# Altium schematic sheet Power.SchDoc
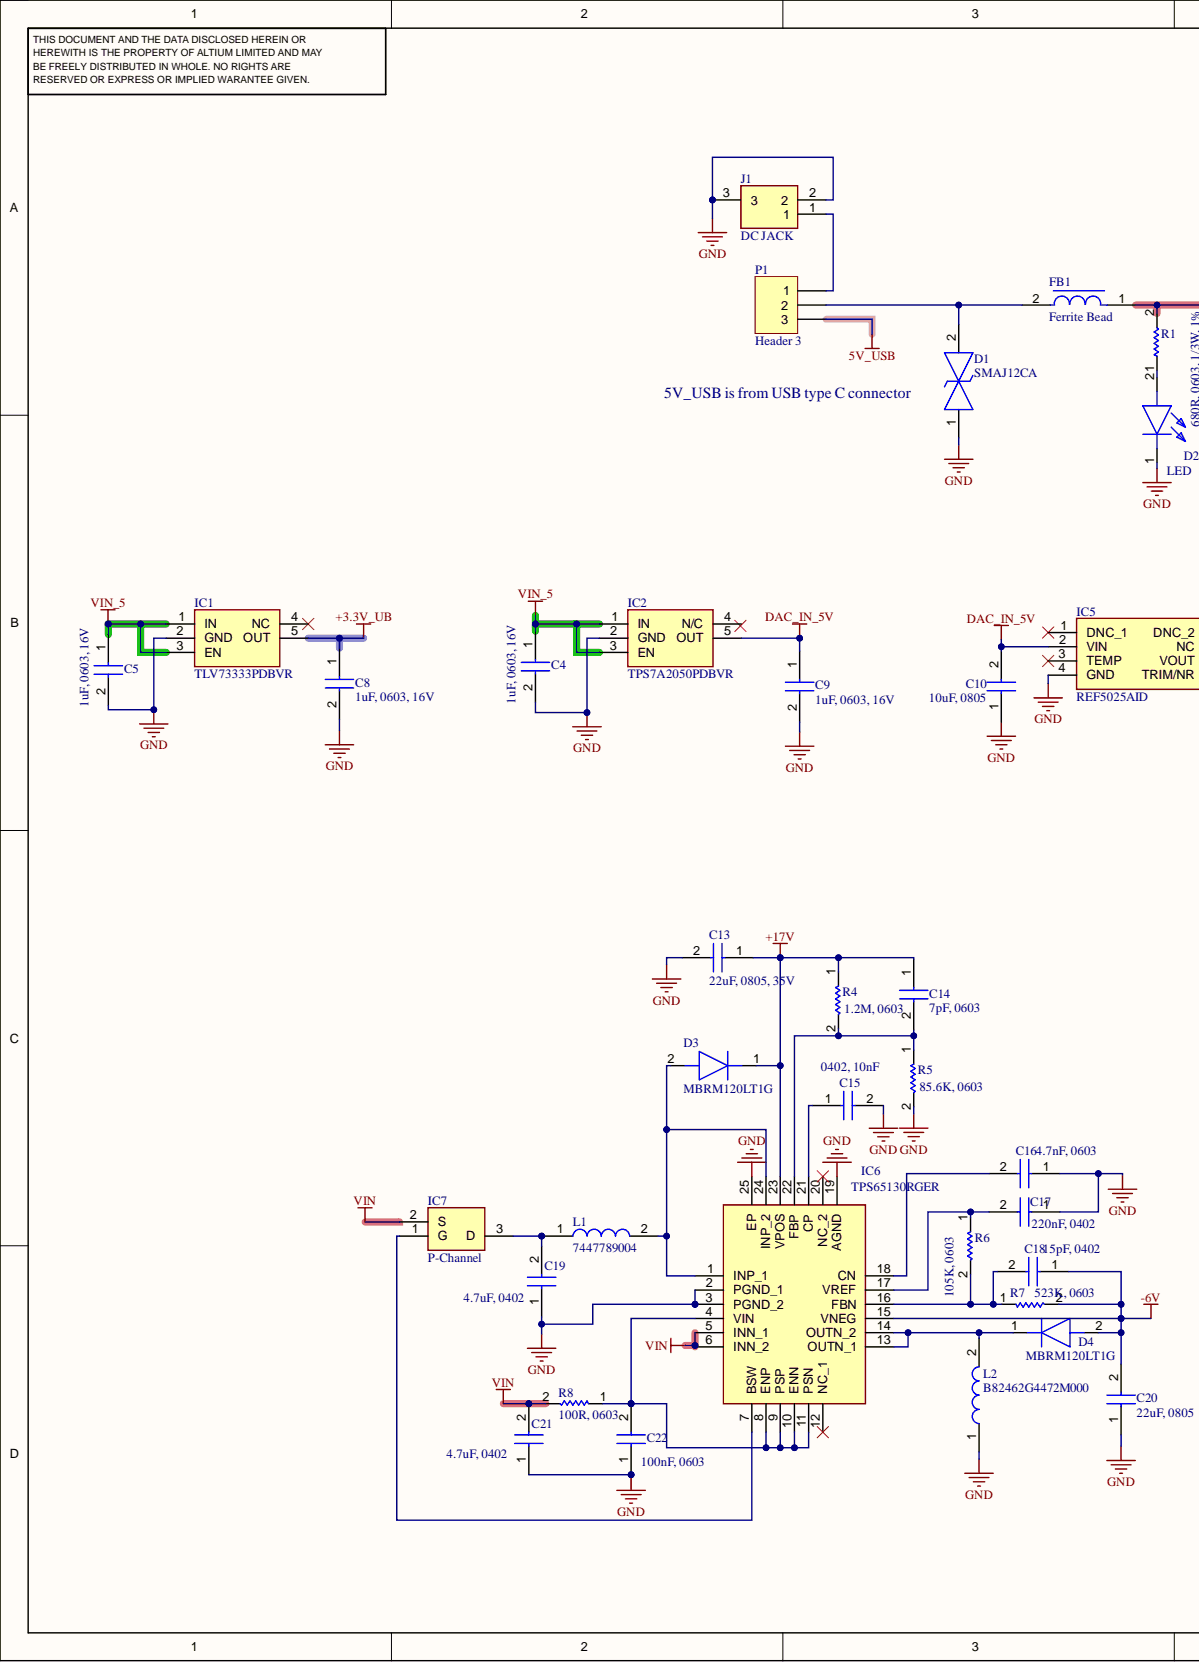
[diagram p0: Power.SchDoc, left]
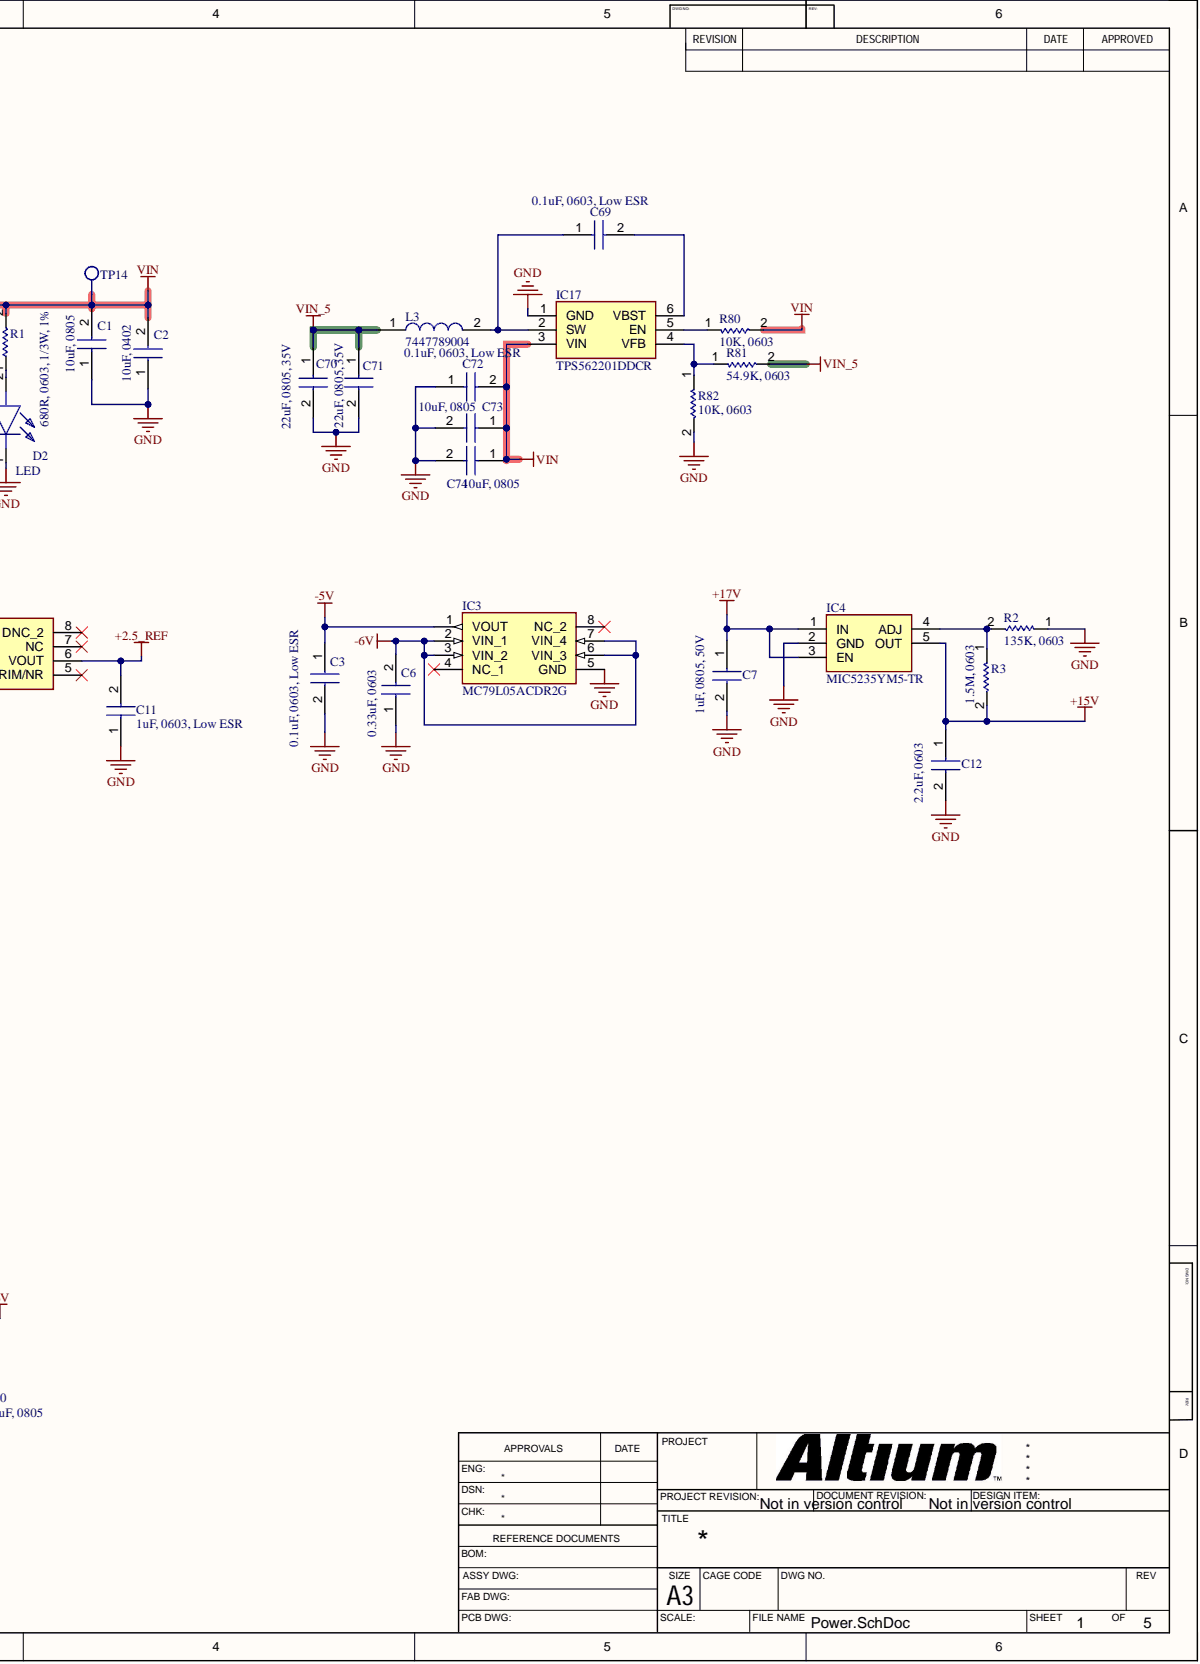
[diagram p1: Power.SchDoc, right]

## Parts
C1  10uF, 0805  [CAP0805]
C2  10uF, 0402  [CAP0402]
C3  0.1uF, 0603, Low ESR  [CAP0603]
C4  1uF, 0603, 16V  [CAP0603]
C5  1uF, 0603, 16V  [CAP0603]
C6  0.33uF, 0603  [CAP0603]
C7  1uF, 0805, 50V  [CAP0805]
C8  1uF, 0603, 16V  [CAP0603]
C9  1uF, 0603, 16V  [CAP0603]
C10  10uF, 0805  [CAP0805]
C11  1uF, 0603, Low ESR  [CAP0603]
C12  2.2uF, 0603  [CAP0603]
C13  22uF, 0805, 35V  [CAP0805]
C14  7pF, 0603  [CAP0603]
C15  0402, 10nF  [CAP0402]
C16  4.7nF, 0603  [CAP0603]
C17  220nF, 0402  [CAP0402]
C18  15pF, 0402  [CAP0402]
C19  4.7uF, 0402  [CAP0402]
C20  22uF, 0805  [CAP0805]
C21  4.7uF, 0402  [CAP0402]
C22  100nF, 0603  [CAP0603]
C69  0.1uF, 0603, Low ESR  [CAP0603]
C70  22uF, 0805, 35V  [CAP0805]
C71  22uF, 0805, 35V  [CAP0805]
C72  0.1uF, 0603, Low ESR  [CAP0603]
C73  10uF, 0805  [CAP0805]
C74  10uF, 0805  [CAP0805]
D1  SMAJ12CA  [DIONM5127X229N]
D2  LED  [LEDC1608X90N]
D3  MBRM120LT1G  [457-04]
D4  MBRM120LT1G  [457-04]
FB1  Ferrite Bead  [BEADC2012X110N]
IC1  TLV73333PDBVR  [SOT95P280X145-5N]
IC2  TPS7A2050PDBVR  [SOT95P280X145-5N]
IC3  MC79L05ACDR2G  [SOIC127P600X175-8N]
IC4  MIC5235YM5-TR  [SOT95P280X145-5N]
IC5  REF5025AID  [SOIC127P600X175-8N]
IC6  TPS65130RGER  [QFN50P400X400X100-25N-D]
IC7  P-Channel  [SOT95P237X112-3N]
IC17  TPS562201DDCR  [SOT95P280X110-6N]
J1  DC JACK  [ADC0021]
L1  7447789004  [WE-PD_7332/7345_PLASTIC_BASE54]
L2  B82462G4472M000  [INDPM6363X300N]
L3  7447789004  [WE-PD_7332/7345_PLASTIC_BASE54]
P1  Header 3  [HDR1X3]
R1  680R, 0603, 1/3W, 1%  [RES0603]
R2  135K, 0603  [RES0603]
R3  1.5M, 0603  [RES0603]
R4  1.2M, 0603  [RES0603]
R5  85.6K, 0603  [RES0603]
R6  105K, 0603  [RES0603]
R7  523K, 0603  [RES0603]
R8  100R, 0603  [RES0603]
R80  10K, 0603  [RES0603]
R81  54.9K, 0603  [RES0603]
R82  10K, 0603  [RES0603]
TP14  Test Point  [TP_pad]  (value not drawn)

## Nets
5V_USB: P1.3
+2.5_REF: C11.2 IC5.6(VOUT)
+3.3V_UB: C8.1 IC1.5(OUT)
+15V: C12.1 IC4.5(OUT) R3.2
+17V: C7.1 C13.1 C14.1 D3.1 IC4.1(IN) IC4.3(EN) IC6.23(VPOS) R4.1
-5V: C3.1 IC3.1(VOUT)
-6V: C6.2 C18.1 C20.2 D4.2 IC3.2(VIN_1) IC3.3(VIN_2) IC3.6(VIN_3) IC3.7(VIN_4) IC6.15(VNEG) R7.2
DAC_IN_5V: C9.1 C10.2 IC2.5(OUT) IC5.2(VIN)
GND: C1.1 C2.1 C3.2 C4.2 C5.2 C6.1 C7.2 C8.2 C9.2 C10.1 C11.1 C12.2 C13.2 C15.2 C16.1 C17.1 C19.1 C20.1 C21.1 C22.1 C70.2 C71.2 C72.1 C73.2 C74.2 D1.1 D2.1 IC1.2(GND) IC2.2(GND) IC3.5(GND) IC4.2(GND) IC5.4(GND) IC6.2(PGND_1) IC6.3(PGND_2) IC6.19(AGND) IC6.25(EP) IC17.1(GND) J1.2 J1.3 L2.1 R2.1 R5.2 R82.2
VIN: C1.2 C2.2 C21.2 C72.2 C73.1 C74.1 FB1.1 IC6.5(INN_1) IC6.6(INN_2) IC7.2 IC17.3(VIN) R1.2 R8.2 R80.2 TP14.1
VIN_5: C4.1 C5.1 C70.1 C71.1 IC1.1(IN) IC1.3(EN) IC2.1(IN) IC2.3(EN) L3.1 R81.2
NetC14_2: C14.2 IC6.22(FBP) R4.2 R5.1
NetC15_1: C15.1 IC6.21(CP)
NetC16_2: C16.2 IC6.18(CN)
NetC17_2: C17.2 IC6.17(VREF) R6.1
NetC18_2: C18.2 IC6.16(FBN) R6.2 R7.1
NetC19_2: C19.2 IC7.3 L1.1
NetC22_2: C22.2 IC6.4(VIN) IC6.8(ENP) IC6.9(PSP) IC6.10(ENN) IC6.11(PSN) R8.1
NetC69_1: C69.1 IC17.2(SW) L3.2
NetC69_2: C69.2 IC17.6(VBST)
NetD1_2: D1.2 FB1.2 P1.2
NetD2_2: D2.2 R1.1
NetD3_2: D3.2 IC6.1(INP_1) IC6.24(INP_2) L1.2
NetD4_1: D4.1 IC6.13(OUTN_1) IC6.14(OUTN_2) L2.2
NetIC4_4: IC4.4(ADJ) R2.2 R3.1
NetIC6_7: IC6.7(BSW) IC7.1
NetIC17_4: IC17.4(VFB) R81.1 R82.1
NetIC17_5: IC17.5(EN) R80.1
NetJ1_1: J1.1 P1.1

Not connected: IC1 4(NC); IC2 4(N/C); IC3 4(NC_1) 8(NC_2); IC5 1(DNC_1) 3(TEMP) 5(TRIM/NR) 7(NC) 8(DNC_2); IC6 12(NC_1) 20(NC_2)

## Library data of the parts
C1 C10 C73 C74 = Capacitor: CAP CER 10UF 16V X5R 0805; Manufacturer_Name: Samsung Electro-Mechanics; Manufacturer_Part_Number: CL21A106KOQNNNE; Component Kind: Standard; Library Name: Sanket.SchLib
C2 = Capacitor: CAP CER 10UF 10V X5R 0402; Manufacturer_Name: Murata Electronics; Manufacturer_Part_Number: GRM155R61A106ME11D; Component Kind: Standard; Library Name: Sanket.SchLib
C3 C69 C72 = Capacitor: CAP SMT 0603 0.1UF 16V DC; Manufacturer_Name: NIC Components Corp; Manufacturer_Part_Number: NMC0603X7R104K16TRPF; Component Kind: Standard; Library Name: Sanket.SchLib
C4 C5 C8 C9 = Capacitor: CAP CER 1UF 16V X7R 0603; Manufacturer_Name: Samsung Electro-Mechanics; Manufacturer_Part_Number: CL10B105KO8NNNC; Component Kind: Standard; Library Name: Sanket.SchLib
C6 = Capacitor: CAP CER 0.33UF 50V X7R 0603; Manufacturer_Name: TDK Corporation; Manufacturer_Part_Number: CGA3E3X7R1H334K080AB; Component Kind: Standard; Library Name: Sanket.SchLib
C7 = Capacitor: CAP CER 1UF 50V X7R 0805; Manufacturer_Name: Taiyo Yuden; Manufacturer_Part_Number: UMK212B7105MGHT; Component Kind: Standard; Library Name: Sanket.SchLib
C11 = Capacitor: CAP CER 1UF 10V X5R 0603; Manufacturer_Name: Taiyo Yuden; Manufacturer_Part_Number: LMK107BJ105MAHT; Component Kind: Standard; Library Name: Sanket.SchLib
C12 = Capacitor: CAP CER 2.2UF 50V X5R 0603; Manufacturer_Name: Murata Electronics; Manufacturer_Part_Number: GRM188R61H225KE11D; Component Kind: Standard; Library Name: Sanket.SchLib
C13 C70 C71 = Capacitor: CAP CER 22UF 35V X5R 0805; Manufacturer_Name: TDK Corporation; Manufacturer_Part_Number: C2012X5R1V226M125AC; Component Kind: Standard; Library Name: Sanket.SchLib
C14 = Capacitor: CAP CER 7PF 50V C0G/NP0 0603; Manufacturer_Name: Samsung Electro-Mechanics; Manufacturer_Part_Number: CL10C070CB8NNNC; Component Kind: Standard; Library Name: Sanket.SchLib
C15 = Capacitor: CAP CER 10000PF 16V X7R 0402; Manufacturer_Name: Murata Electronics; Manufacturer_Part_Number: GRM155R71C103KA01D; Component Kind: Standard; Library Name: Sanket.SchLib
C16 = Capacitor: CAP CER 4700PF 50V C0G 0603; Manufacturer_Name: TDK Corporation; Manufacturer_Part_Number: CGA3E2C0G1H472J080AA; Component Kind: Standard; Library Name: Sanket.SchLib
C17 = Capacitor: CAP CER 0.22UF 50V X5R 0402; Manufacturer_Name: Taiyo Yuden; Manufacturer_Part_Number: UMK105BJ224KV-F; Component Kind: Standard; Library Name: Sanket.SchLib
C18 = Capacitor: CAP CER 15PF 50V C0G/NP0 0402; Manufacturer_Name: Murata Electronics; Manufacturer_Part_Number: GJM1555C1H150GB01D; Component Kind: Standard; Library Name: Sanket.SchLib
C19 C21 = Capacitor: CAP CER 4.7UF 10V X5R 0402; Manufacturer_Name: Samsung Electro-Mechanics; Manufacturer_Part_Number: CL05A475MP5NRNC; Component Kind: Standard; Library Name: Sanket.SchLib
C20 = Capacitor: CAP CER 22UF 25V X5R 0805; Manufacturer_Name: Samsung Electro-Mechanics; Manufacturer_Part_Number: CL21A226MAYNNNE; Component Kind: Standard; Library Name: Sanket.SchLib
C22 = Capacitor: CAP CER 0.1UF 16V X7R 0603; Manufacturer_Name: YAGEO; Manufacturer_Part_Number: CC0603KRX7R7BB104; Component Kind: Standard; Library Name: Sanket.SchLib
D1 = SMAJ12CA: TVS Diode (Bi-directional); Manufacturer_Name: Bourns; Arrow Part Number: SMAJ12CA; Arrow Price/Stock: https://www.arrow.com/en/products/smaj12ca/bourns?utm_currency=USD&region=europe; Component Kind: Standard; Datasheet Link: https://www.bourns.com/data/global/pdfs/SMAJ.pdf; Height: 2.29mm; Manufacturer_Part_Number: SMAJ12CA; Mouser Part Number: 652-SMAJ12CA; Mouser Price/Stock: https://www.mouser.co.uk/ProductDetail/Bourns/SMAJ12CA?qs=A3H9pljuJ8QXtI4Ziid8eA%3D%3D; Library Name: Sanket.SchLib
D2 = LTST-C190GKT: Manufacturer_Name: Lite-On; Component Kind: Standard; Description: LED; Manufacturer_Part_Number: LTST-C190GKT
D3 D4 = MBRM120LT1G: Diode; Manufacturer_Name: onsemi; Component Kind: Standard; Manufacturer_Part_Number: MBRM120LT1G
FB1 = MI0805K601R-10: Manufacturer_Name: Laird-Signal Integrity Products; Component Kind: Standard; Description: Ferrite Bead; Manufacturer_Part_Number: MI0805K601R-10; Library Name: Sanket.SchLib
IC1 = TLV73333PDBVR: Integrated Circuit; Manufacturer_Name: Texas Instruments; Arrow Part Number: TLV73333PDBVR; Arrow Price/Stock: https://www.arrow.com/en/products/tlv73333pdbvr/texas-instruments?utm_currency=USD&region=europe; Component Kind: Standard; Datasheet Link: http://www.ti.com/lit/gpn/tlv733p; Height: 1.45mm; Manufacturer_Part_Number: TLV73333PDBVR; Mouser Part Number: 595-TLV73333PDBVR; Mouser Price/Stock: https://www.mouser.co.uk/ProductDetail/Texas-Instruments/TLV73333PDBVR?qs=ifm8N2KdrcbBdt%252B3Md%252BMzA%3D%3D; Library Name: Sanket.SchLib
IC2 = TPS7A2050PDBVR: Integrated Circuit; Manufacturer_Name: Texas Instruments; Arrow Part Number: TPS7A2050PDBVR; Arrow Price/Stock: https://www.arrow.com/en/products/tps7a2050pdbvr/texas-instruments?utm_currency=USD&region=nac; Component Kind: Standard; Datasheet Link: https://www.ti.com/lit/gpn/tps7a20?HQS=ti-null-null-sf-df-pf-sep-wwe&DCM=yes; Height: 1.45mm; Manufacturer_Part_Number: TPS7A2050PDBVR; Mouser Part Number: 595-TPS7A2050PDBVR; Mouser Price/Stock: https://www.mouser.co.uk/ProductDetail/Texas-Instruments/TPS7A2050PDBVR?qs=hd1VzrDQEGgpoyZ%2F5FZYnQ%3D%3D; Library Name: Sanket.SchLib
IC3 = MC79L05ACDR2G: Integrated Circuit; Manufacturer_Name: onsemi; Arrow Part Number: MC79L05ACDR2G; Arrow Price/Stock: https://www.arrow.com/en/products/mc79l05acdr2g/on-semiconductor; Component Kind: Standard; Datasheet Link: https://www.onsemi.com/pub/Collateral/MC79L00-D.PDF; Height: 1.75mm; Manufacturer_Part_Number: MC79L05ACDR2G; Mouser Part Number: 863-MC79L05ACDR2G; Mouser Price/Stock: https://www.mouser.co.uk/ProductDetail/onsemi/MC79L05ACDR2G?qs=%252B9%2Fcbd0IE0RF56w%2FNOqBRg%3D%3D; Library Name: Sanket.SchLib
IC4 = MIC5235YM5-TR: Integrated Circuit; Manufacturer_Name: Microchip; Arrow Part Number: MIC5235YM5-TR; Arrow Price/Stock: https://www.arrow.com/en/products/mic5235ym5-tr/microchip-technology?region=nac; Component Kind: Standard; Datasheet Link: https://ww1.microchip.com/downloads/en/DeviceDoc/mic5235.pdf; Height: 1.45mm; Manufacturer_Part_Number: MIC5235YM5-TR; Mouser Part Number: 998-MIC5235YM5TR; Mouser Price/Stock: https://www.mouser.co.uk/ProductDetail/Microchip-Technology/MIC5235YM5-TR?qs=U6T8BxXiZAUVJr63gL5Lnw%3D%3D; Library Name: Sanket.SchLib
IC5 = REF5025AID: Integrated Circuit; Manufacturer_Name: Texas Instruments; Arrow Part Number: REF5025AID; Arrow Price/Stock: https://www.arrow.com/en/products/ref5025aid/texas-instruments?region=nac; Component Kind: Standard; Datasheet Link: http://www.ti.com/lit/ds/symlink/ref5025.pdf; Height: 1.75mm; Manufacturer_Part_Number: REF5025AID; Mouser Part Number: 595-REF5025AID; Mouser Price/Stock: https://www.mouser.co.uk/ProductDetail/Texas-Instruments/REF5025AID?qs=xL7PkI2RoJ6OzMm6G7%2FePw%3D%3D; Library Name: Sanket.SchLib
IC6 = TPS65130RGER: Integrated Circuit; Manufacturer_Name: Texas Instruments; Component Kind: Standard; Manufacturer_Part_Number: TPS65130RGER
IC7 = SI2323DS-T1-BE3: P-CHANNEL 20-V (D-S) MOSFET; Manufacturer_Name: Vishay Siliconix; Manufacturer_Part_Number: SI2323DDS-T1-BE3; Component Kind: Standard
IC17 = TPS562201DDCR: Integrated Circuit; Manufacturer_Name: Texas Instruments; Arrow Part Number: TPS562201DDCR; Arrow Price/Stock: https://www.arrow.com/en/products/tps562201ddcr/texas-instruments?utm_currency=USD&region=nac; Component Kind: Standard; Datasheet Link: http://www.ti.com/lit/gpn/tps562201; Height: 1.1mm; Manufacturer_Part_Number: TPS562201DDCR; Mouser Part Number: 595-TPS562201DDCR; Mouser Price/Stock: https://www.mouser.co.uk/ProductDetail/Texas-Instruments/TPS562201DDCR?qs=8%2FmU9qzJpL8znxxpy0ZjNg%3D%3D
J1 = ADC-002-1: DC POWER JACK, RA, BLACK; Manufacturer_Name: Adam Tech; Component Kind: Standard; Manufacturer_Part_Number: ADC-002-1; Library Name: Sanket.SchLib
L1 L3 = 7447789004: Inductor; Manufacturer_Name: Wurth Elektronik; Component Kind: Standard; Manufacturer_Part_Number: 7447789004
L2 = B82462G4472M000: Inductor; Manufacturer_Name: TDK; Component Kind: Standard; Manufacturer_Part_Number: B82462G4472M000
P1 = Header 3: Header, 3-Pin; Manufacturer_Name: Amphenol ICC (FCI); Manufacturer_Part_Number: 68001-426HLF; Component Kind: Standard; Library Name: Miscellaneous Connectors.IntLib; Signal Integrity: Connector
R1 = Resistor 0603: RES SMD 680 OHM 1% 1/3W 0603; Manufacturer_Name: Vishay Dale; Manufacturer_Part_Number: CRCW0603680RFKEAHP; Component Kind: Standard; Library Name: Sanket.SchLib
R2 = Resistor 0603: RES 135K OHM 0.1% 0.21W 0603; Manufacturer_Name: Vishay Dale; Manufacturer_Part_Number: TNPW0603135KBEEA; Component Kind: Standard; Library Name: Sanket.SchLib
R3 = Resistor 0603: RES 1.5M OHM 1% 1/10W 0603; Manufacturer_Name: YAGEO; Manufacturer_Part_Number: RC0603FR-071M5L; Component Kind: Standard; Library Name: Sanket.SchLib
R4 = Resistor 0603: RES 1.2M OHM 1% 1/10W 0603; Manufacturer_Name: YAGEO; Manufacturer_Part_Number: RC0603FR-071M2L; Component Kind: Standard; Library Name: Sanket.SchLib
R5 = Resistor 0603: RES 85.6K OHM 1% 1/10W 0603; Manufacturer_Name: KOA Speer Electronics, Inc.; Manufacturer_Part_Number: RN73R1JTTD8562F100; Component Kind: Standard; Library Name: Sanket.SchLib
R6 = Resistor 0603: RES SMD 105K OHM 1% 1/10W 0603; Manufacturer_Name: YAGEO; Manufacturer_Part_Number: AC0603FR-07105KL; Component Kind: Standard; Library Name: Sanket.SchLib
R7 = Resistor 0603: RES SMD 523K OHM 1% 1/10W 0603; Manufacturer_Name: Panasonic Electronic Components; Manufacturer_Part_Number: ERJ-3EKF5233V; Component Kind: Standard; Library Name: Sanket.SchLib
R8 = Resistor 0603: RES SMD 100 OHM 1% 1/8W 0603; Manufacturer_Name: Vishay Dale; Manufacturer_Part_Number: CRCW0603100RFKEA; Component Kind: Standard; Library Name: Sanket.SchLib
R80 R82 = Resistor 0603: RES 10K OHM 1% 1/10W 0603; Manufacturer_Name: YAGEO; Manufacturer_Part_Number: RC0603FR-0710KL; Component Kind: Standard; Library Name: Sanket.SchLib
R81 = Resistor 0603: RES 54.9K OHM 1% 1/10W 0603; Manufacturer_Name: YAGEO; Manufacturer_Part_Number: RC0603FR-0754K9L; Component Kind: Standard; Library Name: Sanket.SchLib
TP14 = Test Point: Component Kind: Standard; Library Name: Sanket.SchLib
